# Altium schematic sheet Power_Supply.SchDoc
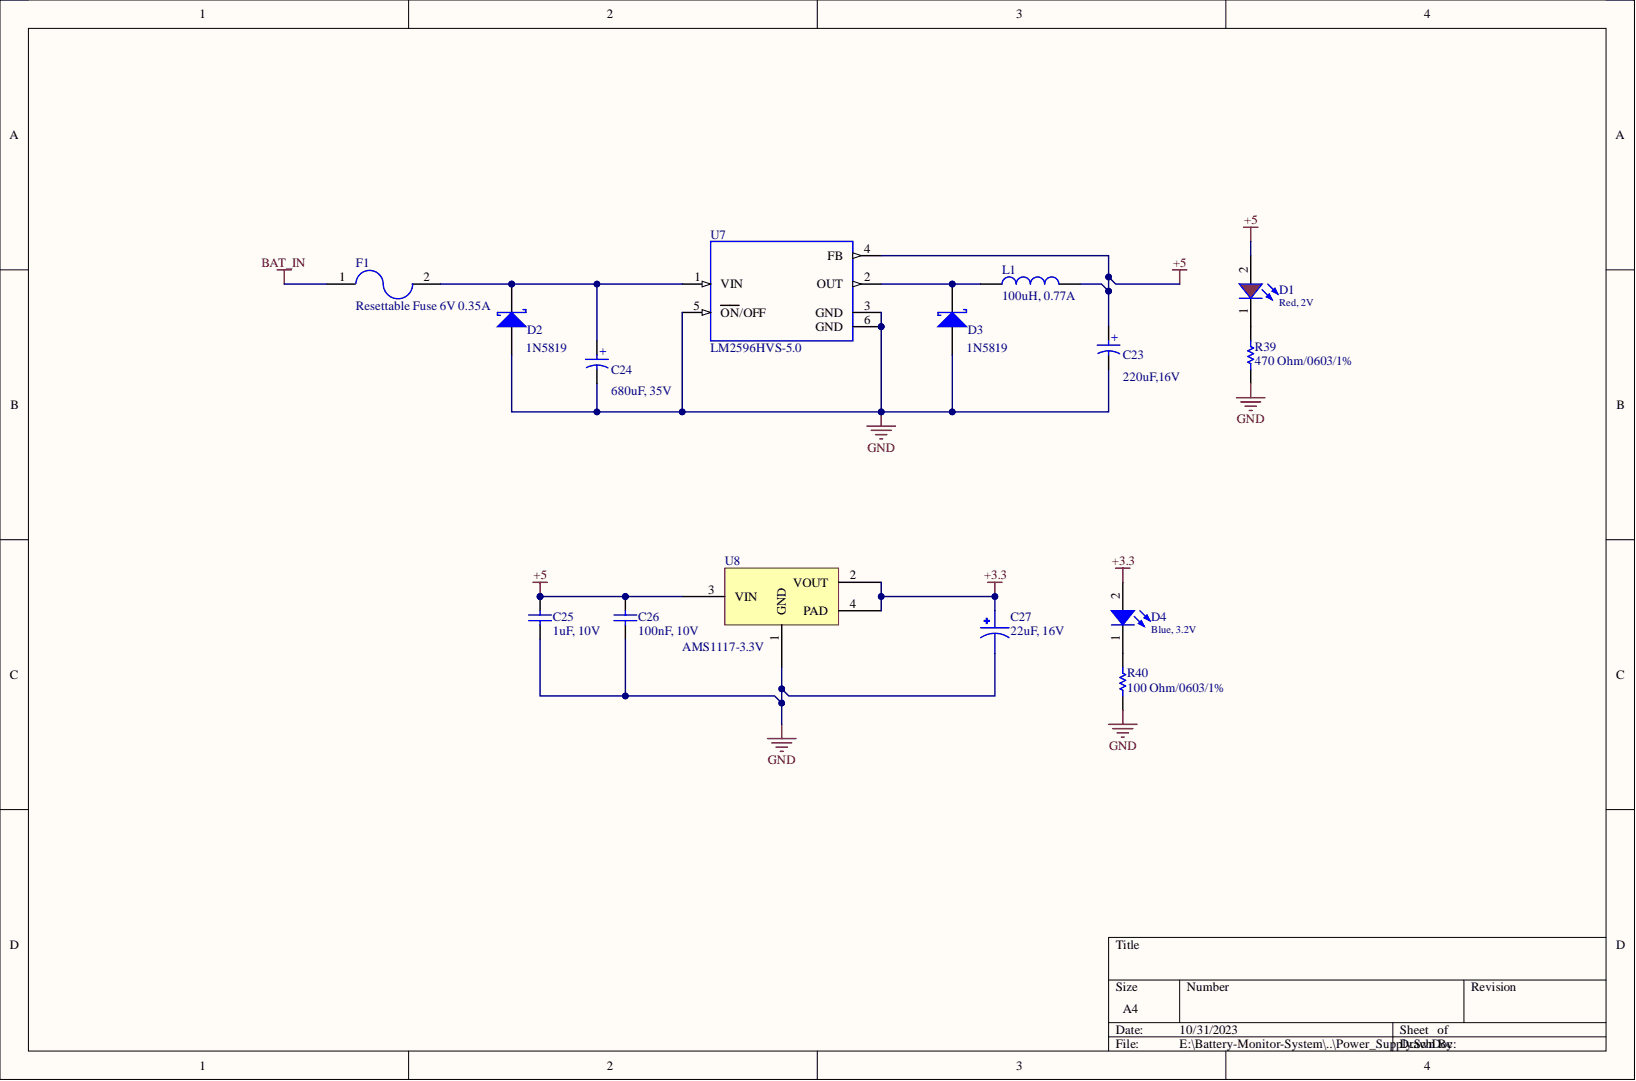
[diagram p0: Power_Supply.SchDoc]

## Parts
C23  220uF  value 220uF,16V  [WCAP-ASLI_D6.3H7.7]
C24  680uF  value 680uF, 35V  [WCAP-ASLI_D12.5H14]
C25  1uF, 10V  [WCAP-CSGP_0603_H=0.8mm_Reflow]
C26  100nF, 10V  [WCAP-CSGP_0603_H=0.8mm_Reflow]
C27  value 22uF, 16V  [C2312]  (hidden comment: Tantalum Capacitor)
D1  Red, 2V  Forward Voltage typ.: 2V  [WL-SMCW_0603 dome]
D2  value 1N5819  [SS34]  (hidden comment: D Schottky)
D3  value 1N5819  [SS34]  (hidden comment: D Schottky)
D4  Blue, 3.2V  [WL-SMCW_0603_H=0.7mm]
F1  value Resettable Fuse 6V 0.35A  [FUSE1206]  (hidden comment: RESETTABLE FUSE 1206)
L1  100uH, 0.77A  Rated Current: 0.77A  [WE-PD2A_7850]
R39  value 470 Ohm/0603/1%  [R0603]  (hidden comment: Resistor 470 Ohm 0603 1%)
R40  value 100 Ohm/0603/1%  [R0603]  (hidden comment: Resistor 100 Ohm 0603 1%)
U7  LM2596  value LM2596HVS-5.0  [TO-263-5]
U8  AMS1117-3.3V  [SOT230P700X180-4N]

## Nets
+3.3: C27.1 D4.2 U8.2(VOUT) U8.4(PAD)
+5: C23.1 C25.2 C26.2 D1.2 L1.2 U7.4(FB) U8.3(VIN)
BAT_IN: F1.1
GND: C23.2 C24.2 C25.1 C26.1 C27.2 D2.1 D3.1 R39.1 R40.1 U7.3(GND) U7.5(ON/OFF) U7.6(GND) U8.1(GND)
NetC24_1: C24.1 D2.2 F1.2 U7.1(VIN)
NetD1_1: D1.1 R39.2
NetD3_2: D3.2 L1.1 U7.2(OUT)
NetD4_1: D4.1 R40.2

## Library data of the parts
C23 = 865080345012: WCAP-ASLI Aluminum Electrolytic Capacitor, V-Chip, D6.3 x H7.7mm, 220uF, 16V; Manufacturer: Wurth Elektronik; Capacitance Tolerance: +/-20%; Dissipation Factor: 16%; Endurance: 2000h; Leakage Current: 35.2uA; Ripple Current: 275mA; Technical Reference: ASED077221M016DVCTCB000; Category: Aluminum Electrolytic Capacitors; Component Kind: Standard; ComponentLink1Description: Website Link; ComponentLink1URL: https://www.we-online.com/redexpert/article/865080345012?ad; ComponentLink2Description: Datasheet Link; ComponentLink2URL: https://www.we-online.com/redexpert/spec/865080345012?ad; Diameter: 6.3mm; Height: 7.7mm; Library Name: Altium_WCAP-ASLI (rev22d).IntLib; Manufacturer Part Number: 865080345012; Match Code: WCAP-ASLI; Mount: Surface Mount; Operating Temperature Max: 105°C; Operating Temperature Min: -55°C; Packaging: Tape and Reel; Rated Voltage (DC): 16V; Simulation: 865080345012_220uF
C24 = 865080562017: WCAP-ASLI Aluminum Electrolytic Capacitor, V-Chip, D12.5 x H14mm, 680uF, 35V; Manufacturer: Wurth Elektronik; Capacitance Tolerance: +/-20%; Dissipation Factor: 14%; Endurance: 5000h; Leakage Current: 238uA; Ripple Current: 1100mA; Technical Reference: ASEH140681M035DVCTGE000; Category: Aluminum Electrolytic Capacitors; Component Kind: Standard; ComponentLink1Description: Website Link; ComponentLink1URL: https://www.we-online.com/redexpert/article/865080562017?ad; ComponentLink2Description: Datasheet Link; ComponentLink2URL: https://www.we-online.com/redexpert/spec/865080562017?ad; Diameter: 12.5mm; Height: 14mm; Library Name: Altium_WCAP-ASLI (rev22d).IntLib; Manufacturer Part Number: 865080562017; Match Code: WCAP-ASLI; Mount: Surface Mount; Operating Temperature Max: 105°C; Operating Temperature Min: -55°C; Packaging: Tape and Reel; Rated Voltage (DC): 35V; Simulation: 865080562017_680uF
C25 = 885012106010: WCAP-CSGP Multilayer Ceramic Chip Capacitor, General Purpose, size 0603, X5R, 1uF, 10V; Manufacturer: Wurth Elektronik; Capacitance Tolerance: +/-20%; Dissipation Factor: #NAME?; Isolation Resistance: >=0.1GOhm; Rated Voltage: 10VDC; Technical Reference: X5R0603105M010DFCT10000; Capacitance: 1uF; Ceramic Type: X5R; Component Kind: Standard; ComponentLink1Description: Manufacturer; ComponentLink1URL: http://www.we-online.de; ComponentLink2Description: Datasheet; ComponentLink2URL: https://www.we-online.com/catalog/datasheet/885012106010.pdf; Height: 0.8mm; Library Name: Altium_WCAP-CSGP (rev20a).IntLib; Manufacturer Part Number: 885012106010; Mounting Technology: SMT; Operating Temperature: -55 to +85degC; Packaging: Tape and Reel; Published Date: May 2020; Size: 0603
C26 = 885012206020: WCAP-CSGP Multilayer Ceramic Chip Capacitor, General Purpose, size 0603, X7R, 100nF, 10V; Manufacturer: Wurth Elektronik; Capacitance Tolerance: +/-10%; Dissipation Factor: #NAME?; Isolation Resistance: >=5GOhm; Rated Voltage: 10VDC; Technical Reference: X7R0603104K010DFCT10000; Capacitance: 100nF; Ceramic Type: X7R; Component Kind: Standard; ComponentLink1Description: Manufacturer; ComponentLink1URL: http://www.we-online.de; ComponentLink2Description: Datasheet; ComponentLink2URL: https://www.we-online.com/catalog/datasheet/885012206020.pdf; Height: 0.8mm; Library Name: Altium_WCAP-CSGP (rev20a).IntLib; Manufacturer Part Number: 885012206020; Mounting Technology: SMT; Operating Temperature: -55 to +125degC; Packaging: Tape and Reel; Published Date: May 2020; Size: 0603
C27 = Tantalum Capacitor: Code 226 10%, 2312 (6032 Metric); MF: AVX; MP: TAJC226K016RNJ; Availability: Warning; Component Kind: Standard; Mouser-Purchase-URL: https://snapeda.com/shop?store=Mouser&id=1681916; Package: 2312 AVX; Price: None; SnapEDA_Link: https://www.snapeda.com/parts/TAJC226K016RNJ/AVX/view-part/1681916/?ref=snap; Library Name: Giang's Libs.IntLib
D1 = 150060RS86000: WL-SMCW SMT Mono-color Chip LED Waterclear, size 0603 dome, Red, 2V, 60deg; Chip Technology: AlInGaP; Dominant Wavelength typ.: 624nm; Luminous Intensity typ.: 800mcd; Peak Wavelength typ.: 632nm; Component Kind: Standard; ComponentLink1Description: Manufacturer; ComponentLink1URL: http://www.we-online.de; ComponentLink2Description: Datasheet; ComponentLink2URL: https://www.we-online.com/catalog/datasheet/150060RS86000.pdf; Emitting Color: Red; Library Name: Altium_WL-SMCW (rev20a).IntLib; Mounting Technology: SMT; Operating Temperature: -40 to +85degC; Packaging: Tape and Reel; Part Number: 150060RS86000; Published Date: December 2019; Size: 0603 dome; Viewing Angle: 60deg; Publisher: Wurth Elektronik
D2 D3 = D Schottky: Schottky Diode; Code_JEDEC: DO-214-AA; Component Kind: Standard; LatestRevisionDate: 17-Jul-2002; LatestRevisionNote: Re-released for DXP Platform.; Library Name: PIF_Library.IntLib; PackageDescription: DO-214-AA/SMB; 2 C-Bend Leads; Body 5.3 x 3.6 mm, inc. leads (LxW); PackageReference: SMB; Published: 8-Jun-2000; Publisher: Altium Limited
D4 = 150060BS75000: WL-SMCW SMT Mono-color Chip LED Waterclear, size 0603, Blue, 3.2V, 140deg; Chip Technology: InGaN; Dominant Wavelength typ.: 470nm; Luminous Intensity typ.: 145mcd; Peak Wavelength typ.: 465nm; Component Kind: Standard; ComponentLink1Description: Manufacturer; ComponentLink1URL: http://www.we-online.de; ComponentLink2Description: Datasheet; ComponentLink2URL: https://www.we-online.com/catalog/datasheet/150060BS75000.pdf; Emitting Color: Blue; Forward Voltage typ.: 3.2V; Library Name: Altium_WL-SMCW (rev20a).IntLib; Mounting Technology: SMT; Operating Temperature: -40 to +85degC; Packaging: Tape and Reel; Part Number: 150060BS75000; Published Date: December 2019; Size: 0603; Viewing Angle: 140deg; Publisher: Wurth Elektronik
F1 = RESETTABLE FUSE 1206: Polymeric PTC Resettable Fuse 6V 350mA Ih Surface Mount 1206 _3216 Metric_, Concave; MF: Littelfuse Inc.; MP: 1206L035YR; Value: Resettable Fuse 6V 0.35A; Availability: Unavailable; Component Kind: Standard; Package: 1206 Littelfuse; Price: None; SnapEDA_Link: https://www.snapeda.com/parts/1206L035YR/Littelfuse%20Inc./view-part/225191/?ref=snap; Library Name: Giang's Libs.IntLib
L1 = 78477520: WE-PD2A SMT Power Inductor, size 7850, 100uH, 0.77A; Manufacturer: Wurth Elektronik; DC Resistance max.: 0.43Ohm; DC Resistance typ.: 0.281Ohm; Rated Voltage: 80VDC; Saturation Current: 0.86A; Self Resonant Frequency: 7MHz; Component Kind: Standard; ComponentLink1Description: Manufacturer; ComponentLink1URL: http://www.we-online.de; ComponentLink2Description: Datasheet; ComponentLink2URL: https://www.we-online.com/catalog/datasheet/78477520.pdf; Inductance: 100uH; Library Name: Altium_WE-PD2A (rev20a).IntLib; Manufacturer Part Number: 78477520; Mounting Technology: SMT; Operating Temperature: -40 to +125degC; Packaging: Tape and Reel; Published Date: May 2020; Size: 7850
R39 = Resistor 470 Ohm 0603 1%: Code 471, 1/10W; Component Kind: Standard; ComponentLink1Description: Buy; ComponentLink1URL: https://www.thegioiic.com/dien-tro-470-ohm-0603-1-; Library Name: Giang_Resistor.IntLib
R40 = Resistor 100 Ohm 0603 1%: Code 1000, 1/10W; Component Kind: Standard; ComponentLink1Description: Buy; ComponentLink1URL: https://www.thegioiic.com/dien-tro-100-ohm-0603-1-; Library Name: Giang_Resistor.IntLib
U7 = LM2596: Simple Switcher Power Converter 150-kHz 4V to 60V, 3A Step-Down Voltage Regulator; MF: Texas Instruments; MP: LM2576HVS-5.0/NOPB; Availability: In Stock; Check_prices: https://www.snapeda.com/parts/LM2576HVS-5.0/NOPB/Texas+Instruments/view-part/?ref=eda; Component Kind: Standard; Package: TO-263-5 Texas Instruments; Price: None; Purchase-URL: https://pricing.snapeda.com/search/part/LM2576HVS-5.0/NOPB/?ref=eda; SnapEDA_Link: https://www.snapeda.com/parts/LM2576HVS-5.0/NOPB/Texas+Instruments/view-part/?ref=snap; Library Name: Giang's Libs.IntLib
U8 = AMS1117-3.3V: Component Kind: Standard; Library Name: MiclsLib.IntLib
